# Altium schematic sheet 05EMS0200_Main.SchDoc
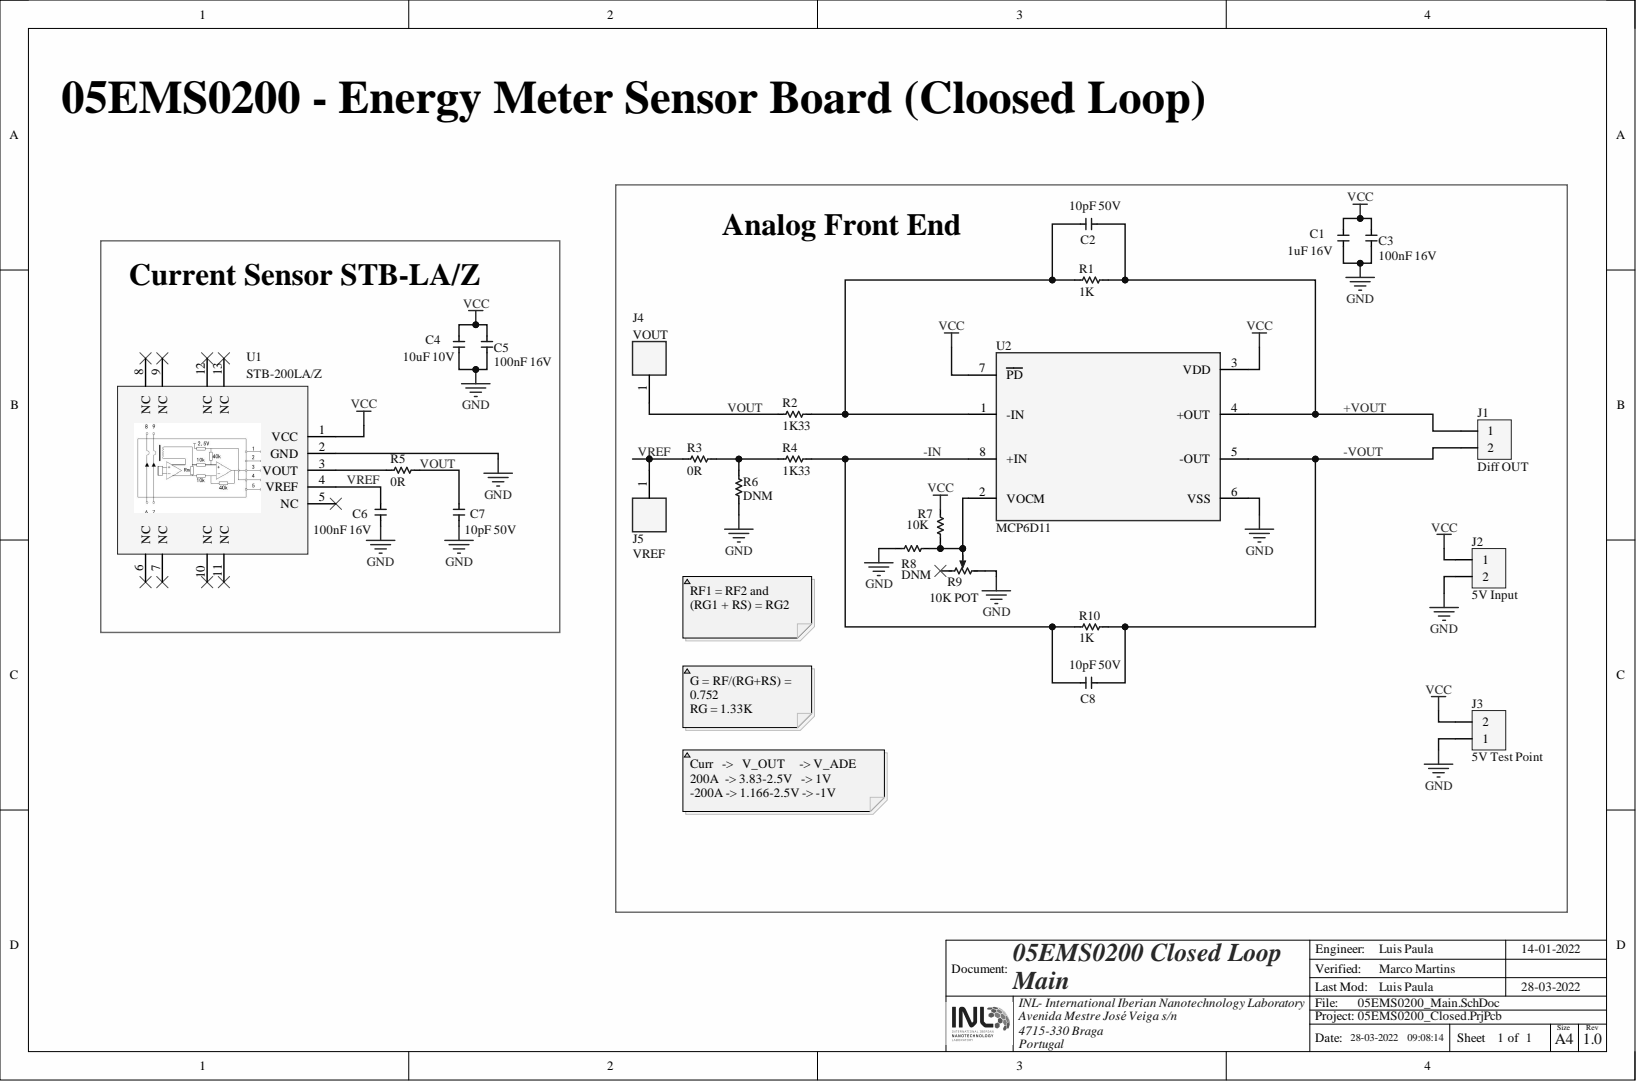
[diagram p0: 05EMS0200_Main.SchDoc]

## Parts
C1  1uF 16V  [0603C]
C2  10pF 50V  [0402C]
C3  100nF 16V  [0402C]
C4  10uF 10V  [0603C]
C5  100nF 16V  [0402C]
C6  100nF 16V  [0402C]
C7  10pF 50V  [0402C]
C8  10pF 50V  [0402C]
J1  Diff OUT  [2Pin Terminal Block]
J2  5V Input  [2Pin Terminal Block]
J3  5V Test Point  [HDR_1x2_2.54MM]
J4  VOUT  [KEYSTONE_5000]
J5  VREF  [KEYSTONE_5000]
R1  1K  [0402R]
R2  1K33  [0402R]
R3  0R  [0402R]
R4  1K33  [0402R]
R5  0R  [0402R]
R6  DNM  [0402R]
R7  10K  [0402R]
R8  DNM  [0402R]
R9  10K POT  [Trimmer_4.8x9.5]
R10  1K  [0402R]
U1  STB-200LA/Z  [STB-LA/Z]
U2  MCP6D11  [MSOP-8]

## Nets
GND: C1.2 C3.1 C4.2 C5.1 C6.1 C7.1 J2.2 J3.1 R6.2 R8.1 R9.3 U1.2(GND) U2.6(VSS)
VCC: C1.1 C3.2 C4.1 C5.2 J2.1 J3.2 R7.2 U1.1(VCC) U2.3(VDD) U2.7(PD)
+VOUT: C2.2 J1.1 R1.1 U2.4(+OUT)
-IN: C8.1 R4.1 R10.2 U2.8(+IN)
-VOUT: C8.2 J1.2 R10.1 U2.5(-OUT)
VOUT: C7.2 J4.1 R2.2 R5.1
VREF: C6.2 J5.1 R3.2 U1.4(VREF)
NetC2_1: C2.1 R1.2 R2.1 U2.1(-IN)
NetR3_1: R3.1 R4.2 R6.1
NetR5_2: R5.2 U1.3(VOUT)
NetR7_1: R7.1 R8.2 R9.2 U2.2(VOCM)

Not connected: R9 1; U1 5(NC) 6(NC) 7(NC) 8(NC) 9(NC) 10(NC) 11(NC) 12(NC) 13(NC)

## Library data of the parts
C1 = Capacitor: Capacitor Ceramic 1uF 16V X5R, SMD 0402; Component Kind: Standard; Library Name: 05EMS0200.SchLib; Type: SMD
C2 C7 C8 = Capacitor: Capacitor Ceramic 10pF 50V NP0, SMD 0402; Component Kind: Standard; Library Name: 05EMS0200.SchLib; Type: SMD
C3 C5 C6 = Capacitor: Capacitor Ceramic 100nF 16V X7R, SMD 0402; Component Kind: Standard; Library Name: 05EMS0200.SchLib; Type: SMD
C4 = Capacitor: Capacitor Ceramic 10uF 10V X5R, SMD 0603; Component Kind: Standard; Library Name: 05EMS0200.SchLib; Type: SMD
J1 J2 = XY2500V-D(5.08)-2PIN: Pluggable Terminal Block, Close Vertical PCB Header, THT, pitch 5.08mm, 2 pins; Manufacturer: Xinya; Component Kind: Standard; ComponentLink1Description: Manufacturer; ComponentLink1URL: http://www.we-online.de; ComponentLink2Description: Datasheet; ComponentLink2URL: https://katalog.we-online.de/em/datasheet/691311500102.pdf; Library Name: 05EMS0200.SchLib; P/N: XY2500V-D(5.08)-2PIN; TME: TBG-5-PW-2P-GN
J3 = Header 2: Header 2-Pin, 2.54mm; Component Kind: Standard; Library Name: 05EMS0200.SchLib; Signal Integrity: Connector; Type: DIP
J4 J5 = 5000: PCB Test Point; Manufacturer: Keystone; Component Kind: Standard; Library Name: 05EMS0200.SchLib; P/N: 5000
R1 R10 = Resistor: Resistor 1K 0402; Component Kind: Standard; Library Name: 05EMS0200.SchLib; Signal Integrity: Res; Simulation: RESISTOR; Type: SMD
R2 R4 = Resistor: Resistor 1K33 0402; Component Kind: Standard; Library Name: 05EMS0200.SchLib; Signal Integrity: Res; Simulation: RESISTOR; Type: SMD
R3 R5 R6 = Resistor: Resistor 0R 0402; Component Kind: Standard; Library Name: 05EMS0200.SchLib; Signal Integrity: Res; Simulation: RESISTOR; Type: SMD
R7 R8 = Resistor: Resistor 10K 0402; Component Kind: Standard; Library Name: 05EMS0200.SchLib; Signal Integrity: Res; Simulation: RESISTOR; Type: SMD
R9 = PV36W103C01B00: Trimmer 10K 10%, 500mW; Component Kind: Standard; ComponentLink1Description: Manufacturer Link; ComponentLink1URL: http://bourns.com/2/search.html; Library Name: 05EMS0200.SchLib
U1 = STB-200LA/Z: Current Sensor based on TMR (Tunneling Magnetoresistance) technology 100A, Closed loop design. DC, AC, pulsed and irregular wave shape measurement.; Manufacturer: Sinomags; Component Kind: Standard; Library Name: 05EMS0200.SchLib; P/N: STB-200LA/Z
U2 = MCP6D11-E/MS: Low-Noise, Precision, 90 MHz Differential I/O Amplifier, MSOP-8, 3x3mm; Manufacturer: Microchip; Component Kind: Standard; Library Name: 05EMS0200.SchLib; P/N: MCP6D11-E/MS
